# Altium schematic sheet Schematic_Orbita_Microcontroller.SchDoc (continued)

## Library data of the parts
C13 C14 = CMP-2008-02679-1: Manufacturer: AVX Interconnect / Elco; Dielectric: C0G; Insulation Resistance: 100GR; Temperature Coefficient: 30ppm/°C; Voltage: 50V; Case Code (Imperial): 0402; Case Code (Metric): 1005; Case/Package: 0402; Category: Ceramic Capacitors; Component Kind: Standard; ComponentLink1Description: https://datasheet.ciiva.com/11788/0900766b80def0e2-11788526.pdf?src-supplier=RSComponents; ComponentLink1URL: https://datasheet.ciiva.com/11788/0900766b80def0e2-11788526.pdf?src-supplier=RSComponents; ComponentLink2Description: https://datasheet.ciiva.com/2987/ccog-2987665.pdf?src-supplier=Avnet; ComponentLink2URL: https://datasheet.ciiva.com/2987/ccog-2987665.pdf?src-supplier=Avnet; ComponentLink3Description: https://datasheet.ciiva.com/151/ccog-151780.pdf?src-supplier=Digi-Key; ComponentLink3URL: https://datasheet.ciiva.com/151/ccog-151780.pdf?src-supplier=Digi-Key; ComponentLink4Description: https://datasheet.ciiva.com/1123/84496-1123652.pdf?src-supplier=Element14; ComponentLink4URL: https://datasheet.ciiva.com/1123/84496-1123652.pdf?src-supplier=Element14; ComponentLink5Description: https://datasheet.ciiva.com/151/ccog-151781.pdf?src-supplier=Digi-Key; ComponentLink5URL: https://datasheet.ciiva.com/151/ccog-151781.pdf?src-supplier=Digi-Key; ComponentLink6Description: https://datasheet.ciiva.com/1123/84496-1123653.pdf?src-supplier=Element14; ComponentLink6URL: https://datasheet.ciiva.com/1123/84496-1123653.pdf?src-supplier=Element14; ComponentLink7Description: https://datasheet.ciiva.com/151/ccog-151782.pdf?src-supplier=Digi-Key; ComponentLink7URL: https://datasheet.ciiva.com/151/ccog-151782.pdf?src-supplier=Digi-Key; Contact Plating: Tin,Matte; Depth: 0.5mm; Height: 0.5mm; Lead Free: Lead Free; Length: 1mm; Library Name: Altium Content Vault; Manufacturer Part Number: 04025A6R8CAT2A; Max Operating Temperature: 125°C; Min Operating Temperature: -55°C; Mount: Surface Mount,PCB; Package Quantity: 1; Packaging: Tape and Reel; Part Number: 04025A6R8CAT2A; Pricing 1: 1=0,24000, 10=0,16300, 100=0,07300, 500=0,05000, 1000=0,04100, 2500=0,03100 (USD); Radiation Hardening: No; REACH SVHC: No SVHC; RoHS: Yes; Stock 1: 58989; Supplier 1: Mouser; Supplier Part Number 1: 581-04025A6R8C; Termination: SMD/SMT; Thickness: 0.022inch; Voltage Rating: 50V; Voltage Rating (DC): 50V; Width: 0.02inch
C15 = CMP-2100-03641-1: Chip Capacitor, 0.1 uF, +/- 10%, 16 V, -55 to 125 degC, 0402 (1005 Metric), RoHS, Tape and Reel; Manufacturer: Murata; Dielectric: X7R; Dielectric Material: Ceramic; Insulation Resistance: 10GR; Voltage: 16V; Case Code (Imperial): 0402; Case Code (Metric): 1005; Case/Package: 0402; Category: Ceramic Capacitors; Component Kind: Standard; ComponentLink1Description: https://datasheet.ciiva.com/129/grm155r71c104ka88-01-129739.pdf?src-supplier=Digi-Key; ComponentLink1URL: https://datasheet.ciiva.com/129/grm155r71c104ka88-01-129739.pdf?src-supplier=Digi-Key; ComponentLink2Description: https://datasheet.ciiva.com/129/grm155r71c104ka88-01-129740.pdf?src-supplier=Digi-Key; ComponentLink2URL: https://datasheet.ciiva.com/129/grm155r71c104ka88-01-129740.pdf?src-supplier=Digi-Key; ComponentLink3Description: https://datasheet.ciiva.com/18102/getdatasheetpartid-85307-18102905.pdf?src-supplier=Verical; ComponentLink3URL: https://datasheet.ciiva.com/18102/getdatasheetpartid-85307-18102905.pdf?src-supplier=Verical; ComponentLink4Description: https://datasheet.ciiva.com/29199/454098-da-01-en-vs-kondensator-0402-29199799.pdf?src-supplier=Conrad; ComponentLink4URL: https://datasheet.ciiva.com/29199/454098-da-01-en-vs-kondensator-0402-29199799.pdf?src-supplier=Conrad; ComponentLink5Description: https://datasheet.ciiva.com/16199/product-16199000.pdf?src-supplier=Future+Electronics; ComponentLink5URL: https://datasheet.ciiva.com/16199/product-16199000.pdf?src-supplier=Future+Electronics; ComponentLink6Description: https://datasheet.ciiva.com/14116/grmseries-highdielectrictype-eng-14116878.pdf?src-supplier=Distrelec; ComponentLink6URL: https://datasheet.ciiva.com/14116/grmseries-highdielectrictype-eng-14116878.pdf?src-supplier=Distrelec; ComponentLink7Description: https://datasheet.ciiva.com/7937/4360-7937615.pdf?src-supplier=Element14; ComponentLink7URL: https://datasheet.ciiva.com/7937/4360-7937615.pdf?src-supplier=Element14; ComponentLink8Description: https://datasheet.ciiva.com/28534/4360-28534133.pdf?src-supplier=Element14; ComponentLink8URL: https://datasheet.ciiva.com/28534/4360-28534133.pdf?src-supplier=Element14; ComponentLink9Description: https://datasheet.ciiva.com/14103/grmseries-highdielectrictype-eng-14103750.pdf?src-supplier=Distrelec; ComponentLink9URL: https://datasheet.ciiva.com/14103/grmseries-highdielectrictype-eng-14103750.pdf?src-supplier=Distrelec; ComponentLink10Description: https://datasheet.ciiva.com/28534/4360-28534127.pdf?src-supplier=Element14; ComponentLink10URL: https://datasheet.ciiva.com/28534/4360-28534127.pdf?src-supplier=Element14; Datasheet URL: http://psearch.en.murata.com/capacitor/product/GRM155R71C104KA88%23.pdf; Datasheet Version: 42675.0; Depth: 0.5mm; Height: 0.5mm; Lead Free: LeadFree; Length: 1mm; Library Name: Altium Content Vault; Manufacturer Part Number: GRM155R71C104KA88D; Manufacturer URL: http://www.murata.com/; Material: Ceramic; Max Operating Temperature: 125degC; Min Operating Temperature: -55degC; Mount: SurfaceMount; Number of Pins: 2; Package Description: 2-Pin Surface Mount Device, Body 1 x 0.5 mm; Package Quantity: 1; Package Reference: 0402; Packaging: TapeandReel; Pricing 1: 1=0,10000, 10=0,02400, 100=0,01000, 500=0,00700, 1000=0,00500, 2500=0,00500 (USD); Radiation Hardening: No; REACH SVHC: NoSVHC; RoHS: Yes; RoHSCompliant: true; Stock 1: 3630519; Supplier 1: Mouser; Supplier Part Number 1: 81-GRM155R71C104KA88; Termination: SMD/SMT; Thickness: 0.022inch; Tolerance: 10%; Voltage Rating: 16V; Voltage Rating (DC): 16V; Width: 0.5mm
C16 C21-C24 C27 = CMP-2008-02046-1: Manufacturer: Kemet; Dielectric: X5R; Dielectric Material: Ceramic; Dissipation Factor: 5%; Tolerance: 10%; Voltage: 10V; Case Code (Imperial): 0402; Case Code (Metric): 1005; Case/Package: 0402; Category: Ceramic Capacitors; Component Kind: Standard; ComponentLink1Description: https://datasheet.ciiva.com/7747/1776086-7747440.pdf?src-supplier=Element14; ComponentLink1URL: https://datasheet.ciiva.com/7747/1776086-7747440.pdf?src-supplier=Element14; ComponentLink2Description: https://datasheet.ciiva.com/144/docfinderpartnumber-c0402c104k8pactu-144097.pdf?src-supplier=Digi-Key; ComponentLink2URL: https://datasheet.ciiva.com/144/docfinderpartnumber-c0402c104k8pactu-144097.pdf?src-supplier=Digi-Key; ComponentLink3Description: https://datasheet.ciiva.com/14595/70095383-14595064.pdf?src-supplier=Allied+Electronics; ComponentLink3URL: https://datasheet.ciiva.com/14595/70095383-14595064.pdf?src-supplier=Allied+Electronics; ComponentLink4Description: https://datasheet.ciiva.com/14588/70095383-14588187.pdf?src-supplier=Allied+Electronics; ComponentLink4URL: https://datasheet.ciiva.com/14588/70095383-14588187.pdf?src-supplier=Allied+Electronics; ComponentLink5Description: https://datasheet.ciiva.com/28645/1789732-28645387.pdf?src-supplier=Element14; ComponentLink5URL: https://datasheet.ciiva.com/28645/1789732-28645387.pdf?src-supplier=Element14; Contact Plating: Tin,Matte; Depth: 0.5mm; Height: 0.5mm; Lead Free: Lead Free; Lead Pitch: 0.3mm; Length: 1mm; Library Name: Altium Content Vault; Manufacturer Part Number: C0402C104K8PACTU; Max Operating Temperature: 85°C; Min Operating Temperature: -55°C; Mount: Surface Mount,PCB; Number of Pins: 2; Package Quantity: 1; Packaging: Tape and Reel; Part Number: C0402C104K8PACTU; Pricing 1: 1=0,25000, 10=0,05500, 100=0,03300, 500=0,02800, 1000=0,02200, 2000=0,01800 (USD); Radiation Hardening: No; REACH SVHC: No SVHC; RoHS: Yes; Stock 1: 975753; Supplier 1: Mouser; Supplier Part Number 1: 80-C0402C104K8P; Termination: SMD/SMT; Thickness: 0.022inch; Voltage Rating: 10V; Voltage Rating (DC): 10V; Width: 0.02inch
C17 = CMP-2008-03070-1: Manufacturer: Kemet; Dielectric: X7R; Dielectric Material: Ceramic; Dissipation Factor: 3.5%; Tolerance: 10%; Voltage: 25V; Case Code (Imperial): 0402; Case Code (Metric): 1005; Case/Package: 0402; Category: Ceramic Capacitors; Component Kind: Standard; ComponentLink1Description: https://datasheet.ciiva.com/45846/docfinderpartnumberc0402c102k5ractu-45846917.pdf?src-supplier=Onlinecomponents.com; ComponentLink1URL: https://datasheet.ciiva.com/45846/docfinderpartnumberc0402c102k5ractu-45846917.pdf?src-supplier=Onlinecomponents.com; ComponentLink2Description: https://datasheet.ciiva.com/7747/kem-c1002-x7r-smd-7747612.pdf?src-supplier=Element14; ComponentLink2URL: https://datasheet.ciiva.com/7747/kem-c1002-x7r-smd-7747612.pdf?src-supplier=Element14; ComponentLink3Description: https://datasheet.ciiva.com/18192/getdatasheetpartid-330802-18192396.pdf?src-supplier=Verical; ComponentLink3URL: https://datasheet.ciiva.com/18192/getdatasheetpartid-330802-18192396.pdf?src-supplier=Verical; Depth: 0.5mm; Height: 0.5mm; Length: 1mm; Library Name: Altium Content Vault; Manufacturer Part Number: C0402C103K3RACTU; Max Operating Temperature: 125°C; Min Operating Temperature: -55°C; Mount: Surface Mount; Package Quantity: 10000; Packaging: Tape and Reel; Part Number: C0402C103K3RACTU; Pricing 1: 1=0,10000, 10=0,01900, 100=0,01100, 500=0,00900, 1000=0,00600, 2000=0,00500 (USD); Radiation Hardening: No; RoHS: Yes; Stock 1: 423830; Supplier 1: Mouser; Supplier Part Number 1: 80-C0402C103K3R; Termination: SMD/SMT; Voltage Rating: 25V; Voltage Rating (DC): 25V; Width: 0.5mm
C18 C19 = CMP-2008-02081-1: Manufacturer: Kemet; Manufacturer Part Number: C0402C105K8PACTU; Dielectric: X5R; Dielectric Material: Ceramic; Dissipation Factor: 5%; Tolerance: 10%; Voltage: 10V; Case Code (Imperial): 0402; Case Code (Metric): 1005; Case/Package: 0402; Category: Ceramic Capacitors; Component Kind: Standard; ComponentLink1Description: https://datasheet.ciiva.com/16623/datasheetpn-c0402c105k8pac7867-16623492.pdf?src-supplier=Avnet+Europe; ComponentLink1URL: https://datasheet.ciiva.com/16623/datasheetpn-c0402c105k8pac7867-16623492.pdf?src-supplier=Avnet+Europe; ComponentLink2Description: https://datasheet.ciiva.com/7747/40889-7747622.pdf?src-supplier=Element14; ComponentLink2URL: https://datasheet.ciiva.com/7747/40889-7747622.pdf?src-supplier=Element14; ComponentLink3Description: https://datasheet.ciiva.com/28610/1918694-28610762.pdf?src-supplier=Element14; ComponentLink3URL: https://datasheet.ciiva.com/28610/1918694-28610762.pdf?src-supplier=Element14; ComponentLink4Description: https://datasheet.ciiva.com/22693/1929483-22693871.pdf?src-supplier=Element14; ComponentLink4URL: https://datasheet.ciiva.com/22693/1929483-22693871.pdf?src-supplier=Element14; ComponentLink5Description: https://datasheet.ciiva.com/28645/1789732-28645391.pdf?src-supplier=Element14; ComponentLink5URL: https://datasheet.ciiva.com/28645/1789732-28645391.pdf?src-supplier=Element14; Contact Plating: Tin,Matte; Depth: 0.5mm; Height: 0.5mm; Lead Free: Lead Free; Length: 1mm; Library Name: Altium Content Vault; Max Operating Temperature: 85°C; Min Operating Temperature: -55°C; Mount: Surface Mount; Number of Pins: 2; Package Quantity: 1; Packaging: Tape and Reel; Part Number: C0402C105K8PAC7867; Pricing 1: 1=0,12000, 10=0,08700, 100=0,04000, 500=0,02800, 1000=0,02300, 2000=0,02000 (USD); Radiation Hardening: No; REACH SVHC: No SVHC; RoHS: Yes; Stock 1: 98757; Supplier 1: Mouser; Supplier Part Number 1: 80-C0402C105K8P; Termination: SMD/SMT; Thickness: 0.022inch; Voltage Rating: 10V; Voltage Rating (DC): 10V; Width: 0.5mm
C20 = CMP-2008-04549-1: Manufacturer: Murata; Dielectric: X5R; Tolerance: 20%; Voltage: 6.3V; Case Code (Imperial): 0402; Case Code (Metric): 1005; Case/Package: 0402; Category: Ceramic Capacitors; Component Kind: Standard; ComponentLink1Description: https://datasheet.ciiva.com/6270/product-6270483.pdf?src-supplier=Digi-Key; ComponentLink1URL: https://datasheet.ciiva.com/6270/product-6270483.pdf?src-supplier=Digi-Key; ComponentLink2Description: https://datasheet.ciiva.com/6268/partnumbering-e-01-6268378.pdf?src-supplier=Digi-Key; ComponentLink2URL: https://datasheet.ciiva.com/6268/partnumbering-e-01-6268378.pdf?src-supplier=Digi-Key; ComponentLink3Description: https://datasheet.ciiva.com/46418/1769893-46418044.pdf?src-supplier=Element14; ComponentLink3URL: https://datasheet.ciiva.com/46418/1769893-46418044.pdf?src-supplier=Element14; ComponentLink4Description: https://datasheet.ciiva.com/46418/1769893-46418045.pdf?src-supplier=Element14; ComponentLink4URL: https://datasheet.ciiva.com/46418/1769893-46418045.pdf?src-supplier=Element14; ComponentLink5Description: https://datasheet.ciiva.com/6268/partnumbering-e-01-6268382.pdf?src-supplier=Digi-Key; ComponentLink5URL: https://datasheet.ciiva.com/6268/partnumbering-e-01-6268382.pdf?src-supplier=Digi-Key; Contact Plating: Tin; Depth: 0.5mm; Height: 0.5mm; Lead Free: Lead Free; Length: 1mm; Library Name: Altium Content Vault; Manufacturer Part Number: GRM155R60J475ME47D; Max Operating Temperature: 85°C; Min Operating Temperature: -55°C; Mount: Surface Mount; Package Quantity: 10000; Packaging: Tape and Reel; Part Number: GRM155R60J475ME47D; Pricing 1: 1=0,15000, 10=0,11400, 100=0,05600, 500=0,03500, 1000=0,02900, 2500=0,02700 (USD); REACH SVHC: No SVHC; RoHS: Yes; Stock 1: 911879; Supplier 1: Mouser; Supplier Part Number 1: 81-GRM155R60J475ME7D; Termination: SMD/SMT; Thickness: 0.022inch; Voltage Rating: 6.3V; Voltage Rating (DC): 6.3V; Weight: 0.000212oz; Width: 0.02inch
C25 = CMP-2007-03541-1: Manufacturer: Murata; Dielectric: X5R; Material: Ceramic; Tolerance: 10%; Voltage: 25V; Case Code (Imperial): 0805; Case Code (Metric): 2012; Case/Package: 0805; Category: Ceramic Capacitors; Component Kind: Standard; ComponentLink1Description: https://datasheet.ciiva.com/28584/626718-28584288.pdf?src-supplier=Element14; ComponentLink1URL: https://datasheet.ciiva.com/28584/626718-28584288.pdf?src-supplier=Element14; ComponentLink2Description: https://datasheet.ciiva.com/16440/grm21br61e106ka73l-16440627.pdf?src-supplier=Future+Electronics; ComponentLink2URL: https://datasheet.ciiva.com/16440/grm21br61e106ka73l-16440627.pdf?src-supplier=Future+Electronics; ComponentLink3Description: https://datasheet.ciiva.com/5424/product-5424327.pdf?src-supplier=Mouser; ComponentLink3URL: https://datasheet.ciiva.com/5424/product-5424327.pdf?src-supplier=Mouser; ComponentLink4Description: https://datasheet.ciiva.com/22774/1747469-22774396.pdf?src-supplier=Farnell; ComponentLink4URL: https://datasheet.ciiva.com/22774/1747469-22774396.pdf?src-supplier=Farnell; ComponentLink5Description: https://datasheet.ciiva.com/29199/454623-da-01-en-vs-kondensator-0805-29199615.pdf?src-supplier=Conrad; ComponentLink5URL: https://datasheet.ciiva.com/29199/454623-da-01-en-vs-kondensator-0805-29199615.pdf?src-supplier=Conrad; ComponentLink6Description: https://datasheet.ciiva.com/28584/626718-28584279.pdf?src-supplier=Element14; ComponentLink6URL: https://datasheet.ciiva.com/28584/626718-28584279.pdf?src-supplier=Element14; ComponentLink7Description: https://datasheet.ciiva.com/139/partnumbering-e-01-139182.pdf?src-supplier=Digi-Key; ComponentLink7URL: https://datasheet.ciiva.com/139/partnumbering-e-01-139182.pdf?src-supplier=Digi-Key; ComponentLink8Description: https://datasheet.ciiva.com/139/partnumbering-e-01-139184.pdf?src-supplier=Digi-Key; ComponentLink8URL: https://datasheet.ciiva.com/139/partnumbering-e-01-139184.pdf?src-supplier=Digi-Key; ComponentLink9Description: https://datasheet.ciiva.com/7719/1747469-7719093.pdf?src-supplier=Element14; ComponentLink9URL: https://datasheet.ciiva.com/7719/1747469-7719093.pdf?src-supplier=Element14; ComponentLink10Description: https://datasheet.ciiva.com/14116/grmseries-highdielectrictype-eng-14116376.pdf?src-supplier=Distrelec; ComponentLink10URL: https://datasheet.ciiva.com/14116/grmseries-highdielectrictype-eng-14116376.pdf?src-supplier=Distrelec; ComponentLink11Description: https://datasheet.ciiva.com/139/partnumbering-e-01-139183.pdf?src-supplier=Digi-Key; ComponentLink11URL: https://datasheet.ciiva.com/139/partnumbering-e-01-139183.pdf?src-supplier=Digi-Key; Depth: 1.25mm; Height: 1.25mm; Lead Free: Lead Free; Length: 2mm; Library Name: Altium Content Vault; Manufacturer Part Number: GRM21BR61E106KA73L; Max Operating Temperature: 85°C; Min Operating Temperature: -55°C; Mount: Surface Mount; Package Quantity: 1; Packaging: Tape and Reel; Part Number: GRM21BR61E106KA73L; Pricing 1: 1=0,39000, 10=0,26700, 100=0,17500, 500=0,13100, 1000=0,11100, 3000=0,09100 (USD); Radiation Hardening: No; REACH SVHC: Unknown; RoHS: Yes; Stock 1: 211262; Supplier 1: Mouser; Supplier Part Number 1: 81-GRM21BR61E106KA3L; Termination: SMD/SMT; Thickness: 0.053inch; Voltage Rating: 25V; Voltage Rating (DC): 25V; Width: 0.049inch
C26 = CMP-1036-04939-1: CAP 22uF 6.3V ±20% 0805 (2012 Metric) Thickness 1.45mm SMD; Manufacturer: Kyocera AVX; Manufacturer Part Number: 08056D226MAT2A; Case-EIA: 0805; Case-Metric: 2012; Dielectric: X5R; Dielectric Material: Ceramic; Dissipation Factor: 12.5%; Insulation Resistance: 10GR; Tolerance: Â±20%; Case Code (Imperial): 0805; Case Code (Metric): 2012; Case/Package: 0805; Category: Ceramic Capacitors; Component Kind: Standard; ComponentLink1Description: https://datasheet.ciiva.com/46385/759405-46385942.pdf?src-supplier=Element14; ComponentLink1URL: https://datasheet.ciiva.com/46385/759405-46385942.pdf?src-supplier=Element14; ComponentLink2Description: https://datasheet.ciiva.com/157/cx5r-157915.pdf?src-supplier=Digi-Key; ComponentLink2URL: https://datasheet.ciiva.com/157/cx5r-157915.pdf?src-supplier=Digi-Key; ComponentLink3Description: https://datasheet.ciiva.com/7812/1598274-7812187.pdf?src-supplier=Element14; ComponentLink3URL: https://datasheet.ciiva.com/7812/1598274-7812187.pdf?src-supplier=Element14; ComponentLink4Description: https://datasheet.ciiva.com/22703/1598274-22703227.pdf?src-supplier=Element14; ComponentLink4URL: https://datasheet.ciiva.com/22703/1598274-22703227.pdf?src-supplier=Element14; ComponentLink5Description: https://datasheet.ciiva.com/2988/cx5r-2988046.pdf?src-supplier=Avnet; ComponentLink5URL: https://datasheet.ciiva.com/2988/cx5r-2988046.pdf?src-supplier=Avnet; ComponentLink6Description: https://datasheet.ciiva.com/28592/759405-28592847.pdf?src-supplier=Element14; ComponentLink6URL: https://datasheet.ciiva.com/28592/759405-28592847.pdf?src-supplier=Element14; ComponentLink7Description: https://datasheet.ciiva.com/7812/lt-7812359.pdf?src-supplier=Element14; ComponentLink7URL: https://datasheet.ciiva.com/7812/lt-7812359.pdf?src-supplier=Element14; ComponentLink8Description: https://datasheet.ciiva.com/14598/70001028-14598969.pdf?src-supplier=Allied+Electronics; ComponentLink8URL: https://datasheet.ciiva.com/14598/70001028-14598969.pdf?src-supplier=Allied+Electronics; ComponentLink9Description: https://datasheet.ciiva.com/157/cx5r-157916.pdf?src-supplier=Digi-Key; ComponentLink9URL: https://datasheet.ciiva.com/157/cx5r-157916.pdf?src-supplier=Digi-Key; ComponentLink10Description: https://datasheet.ciiva.com/157/cx5r-157917.pdf?src-supplier=Digi-Key; ComponentLink10URL: https://datasheet.ciiva.com/157/cx5r-157917.pdf?src-supplier=Digi-Key; Depth: 1.25mm; Height: 1.4mm; Lead Free: Lead Free; Length: 2.01mm; Library Name: Altium Content Vault; Max Operating Temperature: 85°C; Max Thickness: 1.45 mm; Min Operating Temperature: -55°C; Mount: Surface Mount,PCB; Number of Pins: 2; Package Quantity: 1; Packaging: Tape and Reel; Pricing 1: 1=0,70000, 10=0,49200, 100=0,32400, 500=0,24000, 1000=0,20400, 3000=0,16700 (USD); Radiation Hardening: No; Rated Voltage: 6.3 V; REACH SVHC: No SVHC; RoHS: Yes; Stock 1: 220672; Supplier 1: Mouser; Supplier Part Number 1: 581-08056D226M; Technology: SMT; Termination: SMD/SMT; Thickness: 0.055inch; Voltage: 6.3V; Voltage Rating: 6.3V; Voltage Rating (DC): 6.3V; Width: 0.049inch
D1 = CMP-2000-05378-1: Very low capacitance ESD protection, 3.5 pF, -40 to 125 degC, 6-Pin SOT23, RoHS, Tape and Reel; Manufacturer: STMicroelectronics; Breakdown Voltage: 6V; Capacitance: 3.5pF; Clamping Voltage: 17V; Configuration: Dual; Data Rate: 480Mbps; Direction: Unidirectional,Bidirectional; Direction Type: Uni-Directional; ESD Protection: Yes; Leakage Current: 0.15uA; Max Reverse Leakage Current: 150nA; Number of Bidirectional Channels: 2; Number of Elements: 1; Operating Supply Voltage: 1.1V; Peak Pulse Current: 5A; Product Depth (mm): 1.75mm; Product Height (mm): 1.3mm; Product Length (mm): 3.05mm; Rad Hardened: No; Reverse Stand-off Voltage: 5V; Reverse Standoff Voltage: 5.25V; Suppressor Type: DiodeArrays; Test Current: 1mA; Capacitance Value: 3.5pF; Case/Package: SOT-23; Category: TVS Diodes; Clamping Voltage (Max): 17V; Component Kind: Standard; ComponentLink1Description: https://datasheet.ciiva.com/1268/1690354-1268384.pdf?src-supplier=Element14; ComponentLink1URL: https://datasheet.ciiva.com/1268/1690354-1268384.pdf?src-supplier=Element14; ComponentLink2Description: https://datasheet.ciiva.com/4508/cd00050750-113117-4508433.pdf?src-supplier=Mouser; ComponentLink2URL: https://datasheet.ciiva.com/4508/cd00050750-113117-4508433.pdf?src-supplier=Mouser; ComponentLink3Description: https://datasheet.ciiva.com/15143/getdatasheetpartid-148465-15143789.pdf?src-supplier=Verical; ComponentLink3URL: https://datasheet.ciiva.com/15143/getdatasheetpartid-148465-15143789.pdf?src-supplier=Verical; ComponentLink4Description: https://datasheet.ciiva.com/26787/usblc6-2sc6-26787908.pdf?src-supplier=IIIC; ComponentLink4URL: https://datasheet.ciiva.com/26787/usblc6-2sc6-26787908.pdf?src-supplier=IIIC; ComponentLink5Description: https://datasheet.ciiva.com/16200/cd00050750-16200026.pdf?src-supplier=Future+Electronics; ComponentLink5URL: https://datasheet.ciiva.com/16200/cd00050750-16200026.pdf?src-supplier=Future+Electronics; ComponentLink6Description: https://datasheet.ciiva.com/41444/001184109-da-01-en-ic-esd-protecti-usblc6-2sc6-sot-23-6-stm-41444156.pdf?src-supplier=Conrad; ComponentLink6URL: https://datasheet.ciiva.com/41444/001184109-da-01-en-ic-esd-protecti-usblc6-2sc6-sot-23-6-stm-41444156.pdf?src-supplier=Conrad; Contact Plating: Tin,Matte; Datasheet URL: http://www.st.com/st-web-ui/static/active/en/resource/technical/document/datasheet/CD00050750.pdf; Datasheet Version: Rev. 5, 10/2011; Depth: 1.75mm; Element Configuration: Dual; Height: 1.3mm; Lead Free: Lead Free; Leakage Current (Max): 0.15uA; Length: 3.05mm; Library Name: Altium Content Vault; Manufacturer Part Number: USBLC6-2SC6; Manufacturer URL: http://www.st.com/; Max Breakdown Voltage: 6V; Max Operating Temperature: 125°C; Min Breakdown Voltage: 6V; Min Operating Temperature: -40°C; Mount: Surface Mount; Mounting: SurfaceMount; Mounting Style: SurfaceMount; Number of Channels: 2; Number of Pins: 6; Operating Temp Range: -40Cto125C; Operating Temperature Classification: Automotive; Operating Temperature Max Deg. C: 125C; Operating Temperature Min Deg. C: -40C; Package / Case: SOT-23; Package Description: 6-Pin Small Outline Transistor, Body 2.925 x 1.625 mm, Pitch 0.95 mm; Package Quantity: 3000; Package Reference: SOT23-6L; Packaging: TapeandReel; Pin Count: 6; Polarity: Uni-Directional; Power Line Protection: Yes; Pricing 1: 1=0,45000, 10=0,37400, 100=0,22800, 1000=0,17600, 3000=0,15000, 9000=0,14000 (USD); Radiation Hardening: No; REACH SVHC: No SVHC; Reverse Breakdown Voltage: 6V; RoHS: Yes; Stock 1: 29344; Supplier 1: Mouser; Supplier Part Number 1: 511-USBLC6-2SC6; Termination: SMD/SMT; Test Current (It): 1mA; Width: 1.75mm; Working Voltage: 5V; Working Voltage (Max): 5V
D2 = CMP-1060-00363-1: Zener Voltage Regulator, 500 mW, 2-Pin SOD-123, Pb-Free, Tape and Reel; Manufacturer: On Semiconductor; Breakdown Voltage: 3.47V; ESD Protection: Yes; Forward Voltage: 900mV; Impedance: 28R; Material: Plastic; Max Power Dissipation: 500mW; Max Reverse Leakage Current: 25uA; P Max (W): 0.5; Test Current: 20mA; Tolerance: 5%; Voltage Rating (DC): 3.3V; VZ Typ (V): 3.3; Zener Current: 10mA; Case/Package: SOD-123-2; Category: Zener Diodes; Component Kind: Standard; ComponentLink1Description: Manufacturer URL; ComponentLink1URL: http://www.onsemi.com/; ComponentLink2Description: Datasheet; ComponentLink2URL: http://www.onsemi.com/pub_link/Collateral/MMSZ5221BT1-D.PDF; ComponentLink3Description: Package Specification; ComponentLink3URL: http://www.onsemi.com/pub_link/Collateral/425-04.PDF; ComponentLink4Description: https://datasheet.ciiva.com/34303/2011758-34303827.pdf?src-supplier=Jameco; ComponentLink4URL: https://datasheet.ciiva.com/34303/2011758-34303827.pdf?src-supplier=Jameco; ComponentLink5Description: https://datasheet.ciiva.com/15142/getdatasheetpartid-93094-15142584.pdf?src-supplier=Verical; ComponentLink5URL: https://datasheet.ciiva.com/15142/getdatasheetpartid-93094-15142584.pdf?src-supplier=Verical; ComponentLink6Description: https://datasheet.ciiva.com/895/mmsz5221bt1-d-895120.pdf?src-supplier=Digi-Key; ComponentLink6URL: https://datasheet.ciiva.com/895/mmsz5221bt1-d-895120.pdf?src-supplier=Digi-Key; ComponentLink7Description: https://datasheet.ciiva.com/8346/mmsz5221bt1-d-8346168.pdf?src-supplier=Avnet; ComponentLink7URL: https://datasheet.ciiva.com/8346/mmsz5221bt1-d-8346168.pdf?src-supplier=Avnet; ComponentLink8Description: https://datasheet.ciiva.com/16206/mmsz5221bt1-d-16206778.pdf?src-supplier=Future+Electronics; ComponentLink8URL: https://datasheet.ciiva.com/16206/mmsz5221bt1-d-16206778.pdf?src-supplier=Future+Electronics; ComponentLink9Description: https://datasheet.ciiva.com/8346/mmsz5221bt1-d-8346172.pdf?src-supplier=Avnet; ComponentLink9URL: https://datasheet.ciiva.com/8346/mmsz5221bt1-d-8346172.pdf?src-supplier=Avnet; ComponentLink10Description: https://datasheet.ciiva.com/895/mmsz5221bt1-d-895118.pdf?src-supplier=Digi-Key; ComponentLink10URL: https://datasheet.ciiva.com/895/mmsz5221bt1-d-895118.pdf?src-supplier=Digi-Key; ComponentLink11Description: https://datasheet.ciiva.com/46395/86126-46395247.pdf?src-supplier=Element14; ComponentLink11URL: https://datasheet.ciiva.com/46395/86126-46395247.pdf?src-supplier=Element14; ComponentLink12Description: https://datasheet.ciiva.com/25926/mmsz5221bt1-d-25926109.pdf?src-supplier=Avnet+Europe; ComponentLink12URL: https://datasheet.ciiva.com/25926/mmsz5221bt1-d-25926109.pdf?src-supplier=Avnet+Europe; ComponentLink13Description: https://datasheet.ciiva.com/895/mmsz5221bt1-d-895119.pdf?src-supplier=Digi-Key; ComponentLink13URL: https://datasheet.ciiva.com/895/mmsz5221bt1-d-895119.pdf?src-supplier=Digi-Key; Contact Plating: Tin,Matte; DatasheetVersion: Rev. 12; Height: 1.12mm; Lead Free: Lead Free; Length: 2.69mm; Library Name: Altium Content Vault; Manufacturer Part Number: MMSZ5226BT1G; Max Operating Temperature: 150°C; Min Operating Temperature: -55°C; Mounting Technology: Surface Mount; Number of Pins: 2; Package Quantity: 1; PackageDescription: 2-Pin SOD, Body 1.6 x 2.69 mm; PackageReference: SOD-123-2-425-04; PackageVersion: Rev. G, 10/2009; Packaging: Tape and Reel; Packing: Tape and Reel; PartNumber: MMSZ5226BT1G; Peak Reverse Current: 25uA; Power Dissipation: 500mW; Power Rating: 500mW; Pricing 1: 1=0,20000, 10=0,18900, 100=0,06600, 1000=0,04400, 3000=0,03300, 9000=0,02900 (USD); Radiation Hardening: No; REACH SVHC: No SVHC; RoHS: Pb-Free; Stock 1: 37132; Supplier 1: Mouser; Supplier Part Number 1: 863-MMSZ5226BT1G; Voltage Tolerance: 5%; Width: 1.6mm; Working Voltage: 3.3V; Zener Voltage: 3.3V
D4 = APHD1608LVBC/D: Standard LEDs; SMD 0613 Dome Lens LED; 1.6x0.8 mm; Blue; (Cut Reel); Manufacturer: Kingbright; Manufacturer Part Number: APTD1608LVBC/D; Dominant Wavelength: 470nm; Forward Voltage: 2.65V; Lens Color: Colorless; Lens Transparency: Clear; Luminous Intensity: 65mcd; Test Current: 2mA; Viewing Angle: 40°; Case Code (Imperial): 0603; Case Code (Metric): 1608; Case/Package: 0603; Category: LEDs; Color: Blue; Component Kind: Standard; ComponentLink1Description: http://www.mouser.com/ds/2/216/APTD1608LVBC-D-535841.pdf?src-supplier=Mouser; ComponentLink1URL: http://www.mouser.com/ds/2/216/APTD1608LVBC-D-535841.pdf?src-supplier=Mouser; ComponentLink2Description: http://www.kingbrightusa.com/images/catalog/SPEC/APTD1608LVBC-D.pdf?src-supplier=Digi-Key; ComponentLink2URL: http://www.kingbrightusa.com/images/catalog/SPEC/APTD1608LVBC-D.pdf?src-supplier=Digi-Key; Height: 0.95mm; Length: 1.6mm; Mount: Surface Mount; Packaging: Tape and Reel; Pricing 1: 1=0,59000, 10=0,40700, 100=0,29600, 500=0,25700, 1000=0,19800, 2000=0,18700 (USD); RoHS: Yes; Stock 1: 832; Supplier 1: Mouser; Supplier Part Number 1: 604-APTD1608LVBCD; Width: 0.8mm; Library Name: IntegratedLibProject_Orbita.IntLib
J1 = DF11-8DP-2DSA(08): Headers & Wire Housings 2MM V PCB HEADER 8P DR THRU-HOLE TIN; Manufacturer: Hirose; Connector Type: Male Pin; Contact Resistance: 30mR; Current Rating: 2A; Gender: Male; Number of Contacts: 8; Row Spacing: 0.079inch; Voltage Rating: 250V; Category: Board to Board Connectors; Color: Black; Component Kind: Standard; ComponentLink1Description: https://datasheet.ciiva.com/11752/0900766b8080dda0-11752290.pdf?src-supplier=RSComponents; ComponentLink1URL: https://datasheet.ciiva.com/11752/0900766b8080dda0-11752290.pdf?src-supplier=RSComponents; ComponentLink2Description: https://datasheet.ciiva.com/26897/getdatasheetpartid-456442-26897615.pdf?src-supplier=Verical; ComponentLink2URL: https://datasheet.ciiva.com/26897/getdatasheetpartid-456442-26897615.pdf?src-supplier=Verical; ComponentLink3Description: https://datasheet.ciiva.com/16431/en-df11-20130411-16431943.pdf?src-supplier=Future+Electronics; ComponentLink3URL: https://datasheet.ciiva.com/16431/en-df11-20130411-16431943.pdf?src-supplier=Future+Electronics; ComponentLink4Description: https://datasheet.ciiva.com/5154/en-df11-20130411-258217-5154649.pdf?src-supplier=Mouser; ComponentLink4URL: https://datasheet.ciiva.com/5154/en-df11-20130411-258217-5154649.pdf?src-supplier=Mouser; Contact Material: Brass,Copper Alloy; Contact Mating Length: 0.118inch; Contact Plating: Tin; Depth: 5mm; Fastening Type: Detent; Housing Color: Black; Housing Material: Polyamide; Lead Free: Lead Free; Length: 10mm; Manufacturer Part Number: DF11-8DP-2DSA(08); Max Current Rating: 2A; Max Operating Temperature: 85°C; Min Operating Temperature: -30°C; Mount: Through Hole; Number of Positions: 8; Number of Rows: 2; Orientation: Straight; Package Quantity: 100; Packaging: Rail/Tube; Pitch: 2mm; Pricing 1: 10=0,17600, 250=0,13700, 1000=0,10800, 5000=0,08800, 10000=0,08400 (EUR); Pricing 2: 1=0,28000, 10=0,20700, 100=0,16300, 500=0,15600, 1000=0,13000, 2500=0,10400 (USD); Radiation Hardening: No; REACH SVHC: No SVHC; RoHS: Yes; Stock 1: 1010; Stock 2: 2434; Supplier 1: RSComponents; Supplier 2: Mouser; Supplier Part Number 1: 6056857; Supplier Part Number 2: 798-DF11-8DP-2DSA08; Termination: Solder; Library Name: IntegratedSchematicLib_OrbitaProject.SchLib
J2 = CMP-1241-00368-1: 0.5 mm Pitch Easy-On(TM) Type FPC Connector, 2.0 mm Mated Height, Right Angle, ZIF, SMT, Bottom Contact, 6 Circuits, -20 to 85 degC, ELV and RoHS Compliant, Tape and Reel; Manufacturer: Molex; Circuits (Loaded): 6; Contact Resistance: 20mR; Current (Max per Contact): 0.5A; Current Rating: 500mA; Durability (mating cycles max): 20; Entry Angle: 90degrees Angle; Gender: Female; Mated Height: 2.00mm; Material - Metal: Phosphor Bronze; Material - Plating Mating: Gold; PCB Locator: No; PCB Retention: Yes; Pitch: 0.5mm; Pitch - Mating Interface: 0.50mm; Voltage (Max): 50V; Category: FFC / FPC; Component Kind: Standard; ComponentLink1Description: Manufacturer URL; ComponentLink1URL: http://www.molex.com/molex/index.jsp; ComponentLink2Description: Datasheet; ComponentLink2URL: http://www.molex.com/webdocs/datasheets/pdf/en-us/0527460671_FFC_FPC_CONNECTORS.pdf; ComponentLink3Description: Package Specification; ComponentLink3URL: http://www.molex.com/pdm_docs/sd/527460671_sd.pdf; ComponentLink4Description: https://datasheet.ciiva.com/3112/0527460671-ffc-fpc-connectors-3112192.pdf?src-supplier=Avnet; ComponentLink4URL: https://datasheet.ciiva.com/3112/0527460671-ffc-fpc-connectors-3112192.pdf?src-supplier=Avnet; ComponentLink5Description: https://datasheet.ciiva.com/11745/0900766b80dfdcc6-11745364.pdf?src-supplier=RSComponents; ComponentLink5URL: https://datasheet.ciiva.com/11745/0900766b80dfdcc6-11745364.pdf?src-supplier=RSComponents; ComponentLink6Description: https://datasheet.ciiva.com/5137/0527460671-ffc-fpc-connectors-170646-5137484.pdf?src-supplier=Mouser; ComponentLink6URL: https://datasheet.ciiva.com/5137/0527460671-ffc-fpc-connectors-170646-5137484.pdf?src-supplier=Mouser; ComponentLink7Description: https://datasheet.ciiva.com/16659/0527460671-ffc-fpc-connectors-16659910.pdf?src-supplier=Avnet+Europe; ComponentLink7URL: https://datasheet.ciiva.com/16659/0527460671-ffc-fpc-connectors-16659910.pdf?src-supplier=Avnet+Europe; ComponentLink8Description: https://datasheet.ciiva.com/15226/getdatasheetpartid-663713-15226332.pdf?src-supplier=Verical; ComponentLink8URL: https://datasheet.ciiva.com/15226/getdatasheetpartid-663713-15226332.pdf?src-supplier=Verical; Contact Location: Bottom; Contact Material: Bronze; Contact Plating: Gold; Contact Position: Bottom; Depth: 4.3mm; ELV: Compliant; Flammability Rating: UL94 V-0; Housing Color: Natural; Housing Material: Nylon; Length: 9.1mm; Library Name: Altium Content Vault; Manufacturer Part Number: 52746-0671; Material: Bronze; Material - Plating Termination: Gold; Max Operating Temperature: 85°C; Min Operating Temperature: -20°C; Mounting Technology: Surface Mount; Number of Circuits: 6; Number of Contacts: 6; Number of Positions: 6; Number of Rows: 1; Orientation: Right Angle; Package Quantity: 1000; PackageDescription: 6-Lead FPC Connector, Pitch 0.5 mm; PackageReference: 52746-0671; PackageVersion: Rev. H, 05/2009; Packaging: Tape and Reel; Packing: Tape and Reel; PartNumber: 52746-0671; Polarized to PCB: Yes; Pricing 1: 1=0,87000, 10=0,69700, 100=0,60300, 500=0,54200, 1000=0,43600, 2000=0,40600 (USD); REACH SVHC: Unknown; RoHS: TRUE; Stackable: No; Stock 1: 11458; Supplier 1: Mouser; Supplier Part Number 1: 538-52746-0671; Termination: Solder; Voltage Rating: 50V
J3 = CMP-1241-00175-1: 1.0 mm Pitch Easy-On(TM) Type FPC Connector, 2.7 mm Mated Height, Right Angle, ZIF, SMT, Top Contact, 6 Circuits, -30 to 85 degC, ELV and RoHS Compliant, Tape and Reel; Manufacturer: Molex; Circuits (Loaded): 6; Contact Resistance: 20mR; Current (Max per Contact): 1A; Durability (mating cycles max): 30; Entry Angle: 90degrees Angle; Gender: Receptacle; Mated Height: 2.70mm; Material - Metal: Phosphor Bronze; Material - Plating Mating: Gold; Material - Plating Termination: Tin; PCB Locator: No; PCB Retention: Yes; Pitch: 1mm; Pitch - Mating Interface: 1.00mm; Voltage (Max): 125V; Category: FFC / FPC; Component Kind: Standard; ComponentLink1Description: Manufacturer URL; ComponentLink1URL: http://www.molex.com/molex/index.jsp; ComponentLink2Description: Datasheet; ComponentLink2URL: http://www.molex.com/webdocs/datasheets/pdf/en-us/0522070660_FFC_FPC_CONNECTORS.pdf; ComponentLink3Description: Package Specification; ComponentLink3URL: http://www.molex.com/pdm_docs/sd/522070660_sd.pdf; ComponentLink4Description: https://datasheet.ciiva.com/11745/0900766b80d6d4e7-11745263.pdf?src-supplier=RSComponents; ComponentLink4URL: https://datasheet.ciiva.com/11745/0900766b80d6d4e7-11745263.pdf?src-supplier=RSComponents; ComponentLink5Description: https://datasheet.ciiva.com/26929/getdatasheetpartid-761355-26929848.pdf?src-supplier=Verical; ComponentLink5URL: https://datasheet.ciiva.com/26929/getdatasheetpartid-761355-26929848.pdf?src-supplier=Verical; ComponentLink6Description: https://datasheet.ciiva.com/5137/0522070660-ffc-fpc-connectors-149417-5137997.pdf?src-supplier=Mouser; ComponentLink6URL: https://datasheet.ciiva.com/5137/0522070660-ffc-fpc-connectors-149417-5137997.pdf?src-supplier=Mouser; ComponentLink7Description: https://datasheet.ciiva.com/16658/0522070660-ffc-fpc-connectors-16658853.pdf?src-supplier=Avnet+Europe; ComponentLink7URL: https://datasheet.ciiva.com/16658/0522070660-ffc-fpc-connectors-16658853.pdf?src-supplier=Avnet+Europe; ComponentLink8Description: https://datasheet.ciiva.com/1438/522071060-sd-1438423.pdf?src-supplier=Element14; ComponentLink8URL: https://datasheet.ciiva.com/1438/522071060-sd-1438423.pdf?src-supplier=Element14; Contact Material: Bronze; Contact Plating: Gold; Contact Position: Top; Current Rating: 1A; Depth: 8.9mm; ELV: Compliant; Flammability Rating: UL94 V-0; Housing Color: Natural; Housing Material: Nylon; Length: 13.9mm; Library Name: Altium Content Vault; Manufacturer Part Number: 52207-0660; Material: Bronze; Max Operating Temperature: 85°C; Min Operating Temperature: -30°C; Mounting Technology: Surface Mount; Number of Circuits: 6; Number of Contacts: 6; Number of Positions: 6; Number of Rows: 1; Orientation: Right Angle; Package Quantity: 1000; PackageDescription: 6-Lead FPC Connector, Pitch 1.0 mm; PackageReference: 52207-0660; PackageVersion: Rev. F, 03/2007; Packaging: Tape and Reel; Packing: Tape and Reel; PartNumber: 52207-0660; Pitch - Termination Interface: 1.00mm; Polarized to PCB: Yes; Pricing 1: 1=0,84000, 10=0,71400, 100=0,65200, 500=0,54300, 1000=0,46600, 2000=0,43500 (USD); REACH SVHC: Unknown; RoHS: TRUE; Stackable: No; Stock 1: 3668; Supplier 1: Mouser; Supplier Part Number 1: 538-52207-0660; Termination: SMD/SMT; Voltage Rating: 125V
J4 = CMP-2000-05120-1: Micro USB B Type Receptacle, 1.8 A, -55 to 85 degC, 5-Pin SMD, RoHS, Tape and Reel; Manufacturer: Amphenol FCI; Body Orientation: RightAngle; Connector Type: USB,Receptacle; Current Rating: 1.8A; Gender: RCP; Insulation Resistance: 1GR; Max Voltage Rating (AC): 100V; Number of Contacts: 5; Number of Ports: 1; Pitch: 0.65mm; Rad Hard: No; Category: Connectors; Color: Black; Component Kind: Standard; ComponentLink1Description: https://datasheet.ciiva.com/4598/10118194ac-197217-4598807.pdf?src-supplier=Mouser; ComponentLink1URL: https://datasheet.ciiva.com/4598/10118194ac-197217-4598807.pdf?src-supplier=Mouser; ComponentLink2Description: https://datasheet.ciiva.com/26945/getdatasheetpartid-418619-26945695.pdf?src-supplier=Verical; ComponentLink2URL: https://datasheet.ciiva.com/26945/getdatasheetpartid-418619-26945695.pdf?src-supplier=Verical; ComponentLink3Description: https://datasheet.ciiva.com/851/10118194-851110.pdf?src-supplier=Digi-Key; ComponentLink3URL: https://datasheet.ciiva.com/851/10118194-851110.pdf?src-supplier=Digi-Key; ComponentLink4Description: https://datasheet.ciiva.com/851/10118194-851111.pdf?src-supplier=Digi-Key; ComponentLink4URL: https://datasheet.ciiva.com/851/10118194-851111.pdf?src-supplier=Digi-Key; Contact Material: Copper Alloy; Contact Plating: Gold; Datasheet URL: https://datasheet.ciiva.com/26945/getdatasheetpartid-418619-26945695.pdf; Datasheet Version: Rev. A, 10/2011; Depth: 5mm; Features: Shielded; Flammability Rating: UL94 V-0; Housing Material: Polymer; Lead Free: Lead Free; Length: 8.05mm; Library Name: Altium Content Vault; Manufacturer Part Number: 10118194-0001LF; Manufacturer URL: http://www.fci.com/; Max Operating Temperature: 85°C; Min Operating Temperature: -55°C; Mount: Surface Mount,Horizontal; Mounting: SurfaceMount; Number of Terminals: 5; Orientation: Right Angle; Package Description: 5-Pin Surface Mount Device, Body 8.05 x 5.53 mm, Pitch 0.65 mm; Package Quantity: 9600; Package Reference: 10118194-0001LF-5; Packaging: CutTape; Pricing 1: 1=0,43000, 10=0,32000, 100=0,26600, 1200=0,18900, 2400=0,18900, 9600=0,18900 (USD); Radiation Hardening: No; RoHS: Yes; Stock 1: 18174; Supplier 1: Mouser; Supplier Part Number 1: 649-10118194-0001LF; Termination: Solder; Termination Method: Solder; Type: MicroUSBTypeB
J5 J6 = Power_Slot: AWG 22; Component Kind: Standard; Library Name: IntegratedLibProject_Orbita.IntLib
J7-J11 = Prog_Slot: Component Kind: Standard; Library Name: IntegratedLibProject_Orbita.IntLib
L1 = CMP-2000-05964-1: Wire-Wound Chip Power Inductor, 2.2 uH, +/- 20%, -40 to 105 degC, 2-Pin SMD (0805), RoHS, Tape and Reel; Manufacturer: Taiyo Yuden; Core Material: Ferrite; Current - Saturation: 1.1A; Current Rating: 1A; DC Resistance (DCR): 143mOhmMax; EDA / CAD Models: DownloadfromAcceleratedDesigns; Frequency - Self Resonant: 350MHz; Frequency - Test: 1MHz; Online Catalog: BRSeries; Other Names: 587-2905-2  LQBRC2012T2R2MD; Shielding: Unshielded; Type: Wirewound; Case Code (Imperial): 0805; Case Code (Metric): 2012; Case/Package: 0805; Category: Inductors,Coils,Chokes; Component Kind: Standard; ComponentLink1Description: https://datasheet.ciiva.com/6748/brc2012t2r2md-ss-6748784.pdf?src-supplier=Newark; ComponentLink1URL: https://datasheet.ciiva.com/6748/brc2012t2r2md-ss-6748784.pdf?src-supplier=Newark; ComponentLink2Description: https://datasheet.ciiva.com/25607/0900766b810b3c54-25607837.pdf?src-supplier=RSComponents; ComponentLink2URL: https://datasheet.ciiva.com/25607/0900766b810b3c54-25607837.pdf?src-supplier=RSComponents; ComponentLink3Description: https://datasheet.ciiva.com/26870/getdatasheetpartid-639072-26870958.pdf?src-supplier=Verical; ComponentLink3URL: https://datasheet.ciiva.com/26870/getdatasheetpartid-639072-26870958.pdf?src-supplier=Verical; ComponentLink4Description: https://datasheet.ciiva.com/1042/wound07-e-1042307.pdf?src-supplier=Digi-Key; ComponentLink4URL: https://datasheet.ciiva.com/1042/wound07-e-1042307.pdf?src-supplier=Digi-Key; ComponentLink5Description: https://datasheet.ciiva.com/1042/wound07-e-1042308.pdf?src-supplier=Digi-Key; ComponentLink5URL: https://datasheet.ciiva.com/1042/wound07-e-1042308.pdf?src-supplier=Digi-Key; ComponentLink6Description: https://datasheet.ciiva.com/1042/wound07-e-1042306.pdf?src-supplier=Digi-Key; ComponentLink6URL: https://datasheet.ciiva.com/1042/wound07-e-1042306.pdf?src-supplier=Digi-Key; Composition: Wirewound; Datasheet URL: http://ds.yuden.co.jp/TYCOMPAS/ut/detail.do?productNo=BRC2012T2R2MD&fileName=BRC2012T2R2MD_SS&mode=specSheetDownload; Datasheet Version: 09/2016; DC Current: 1A; Depth: 1.45mm; Family: FixedInductors; Halogen Free: Halogen Free; Height: 1.4mm; Height - Seated (Max): 0.055"(1.40mm); Inductance Tolerance: 20%; Lead Free: Lead Free; Lead Pitch: 1mm; Length: 2mm; Library Name: Altium Content Vault; Manufacturer Part Number: BRC2012T2R2MD; Manufacturer URL: http://www.t-yuden.com/; Max DC Current: 1A; Max Operating Temperature: 105°C; Military Standard: Not; Min Operating Temperature: -40°C; Mount: Surface Mount; Mounting Technology: SurfaceMount; Mounting Type: SurfaceMount; Number of Pins: 2; Operating Temperature: -40°C~105°C; Package / Case: 0805(2012Metric); Package Description: 2-Pin Surface Mount Device, Body 2 x 1.25 mm; Package Quantity: 2000; Package Reference: 0805; Packaging: Tape&Reel(TR); Pricing 1: 1=0,34000, 10=0,22500, 100=0,18700, 500=0,17600, 1000=0,13500, 2000=0,12000 (USD); Radiation Hardening: No; REACH SVHC: Unknown; RoHS: Yes; Saturation Current: 1.1A; Self Resonant Frequency: 350MHz; Series: BR; Size / Dimension: 0.079"Lx0.049"W(2.00mmx1.25mm); Standard Package: 2,000; Stock 1: 41696; Supplier 1: Mouser; Supplier Part Number 1: 963-BRC2012T2R2MD; Termination: SMD/SMT; Test Frequency: 1MHz; Tolerance: ±20%; Width: 0.049inch
Q1 = DTC143ZMT2L: Transistor PREBIAS NPN 150MW VMT3; Manufacturer: Rohm; Collector Emitter Breakdown Voltage: 50V; Collector Emitter Voltage (VCEO): 300mV; Continuous Collector Current: 100mA; Element Configuration: Single; hFE Min: 80; Transition Frequency: 250MHz; Case/Package: SOT-723; Category: BJTs; Component Kind: Standard; ComponentLink1Description: https://datasheet.ciiva.com/4461/dtc143ze-210891-4461009.pdf?src-supplier=Mouser; ComponentLink1URL: https://datasheet.ciiva.com/4461/dtc143ze-210891-4461009.pdf?src-supplier=Mouser; ComponentLink2Description: https://datasheet.ciiva.com/987/dtc143z-987431.pdf?src-supplier=Digi-Key; ComponentLink2URL: https://datasheet.ciiva.com/987/dtc143z-987431.pdf?src-supplier=Digi-Key; ComponentLink3Description: https://datasheet.ciiva.com/16432/dtc143ze-16432631.pdf?src-supplier=Future+Electronics; ComponentLink3URL: https://datasheet.ciiva.com/16432/dtc143ze-16432631.pdf?src-supplier=Future+Electronics; ComponentLink4Description: https://datasheet.ciiva.com/987/dtc143z-987432.pdf?src-supplier=Digi-Key; ComponentLink4URL: https://datasheet.ciiva.com/987/dtc143z-987432.pdf?src-supplier=Digi-Key; ComponentLink5Description: https://datasheet.ciiva.com/987/dtc143z-987433.pdf?src-supplier=Digi-Key; ComponentLink5URL: https://datasheet.ciiva.com/987/dtc143z-987433.pdf?src-supplier=Digi-Key; Current Rating: 100mA; Lead Free: Lead Free; Manufacturer Part Number: DTC143ZMT2L; Max Breakdown Voltage: 50V; Max Collector Current: 100mA; Max Operating Temperature: 150°C; Max Output Current: 100mA; Max Power Dissipation: 150mW; Min Operating Temperature: -55°C; Mount: Surface Mount; Number of Pins: 3; Operating Supply Voltage: 50V; Package Quantity: 1; Packaging: Tape and Reel; Polarity: NPN; Power Dissipation: 150mW; Pricing 1: 750=0,07900, 1500=0,05500, 3750=0,05000, 7500=0,04600 (EUR); Radiation Hardening: No; REACH SVHC: No SVHC; RoHS: Yes; Stock 1: 7400; Supplier 1: RSComponents; Supplier Part Number 1: 1246561P; Termination: SMD/SMT; Voltage Rating (DC): 50V; Library Name: IntegratedLibProject_Orbita.IntLib
R12 R14 = CMP-2000-07588-1: Chip Resistor, 10 KOhm, +/- 1%, 0.1 W, -55 to 155 degC, 0402 (1005 Metric), RoHS, Tape and Reel; Manufacturer: Panasonic; Manufacturer Part Number: ERJ-2RKF1002X; Case\/Package: 0402; Max Power Dissipation: 100mW; Temperature Coefficient: 100ppm\/degreesC; Voltage Rating: 50V; Case Code (Imperial): 0402; Case Code (Metric): 1005; Case/Package: 0402; Category: Chip SMD Resistors; Component Kind: Standard; ComponentLink1Description: https://datasheet.ciiva.com/11821/0900766b80f80784-11821171.pdf?src-supplier=RSComponents; ComponentLink1URL: https://datasheet.ciiva.com/11821/0900766b80f80784-11821171.pdf?src-supplier=RSComponents; ComponentLink2Description: https://datasheet.ciiva.com/16199/aoa0000ce2-16199478.pdf?src-supplier=Future+Electronics; ComponentLink2URL: https://datasheet.ciiva.com/16199/aoa0000ce2-16199478.pdf?src-supplier=Future+Electronics; ComponentLink3Description: https://datasheet.ciiva.com/17212/aoa0000ce2-17212102.pdf?src-supplier=Avnet+Europe; ComponentLink3URL: https://datasheet.ciiva.com/17212/aoa0000ce2-17212102.pdf?src-supplier=Avnet+Europe; ComponentLink4Description: https://datasheet.ciiva.com/24023/aoa0000ce2-24023017.pdf?src-supplier=Mouser; ComponentLink4URL: https://datasheet.ciiva.com/24023/aoa0000ce2-24023017.pdf?src-supplier=Mouser; ComponentLink5Description: https://datasheet.ciiva.com/28514/1678782-28514185.pdf?src-supplier=Element14; ComponentLink5URL: https://datasheet.ciiva.com/28514/1678782-28514185.pdf?src-supplier=Element14; ComponentLink6Description: https://datasheet.ciiva.com/43467/1678782-43467402.pdf?src-supplier=Farnell; ComponentLink6URL: https://datasheet.ciiva.com/43467/1678782-43467402.pdf?src-supplier=Farnell; ComponentLink7Description: https://datasheet.ciiva.com/27055/1987020-27055643.pdf?src-supplier=Jameco; ComponentLink7URL: https://datasheet.ciiva.com/27055/1987020-27055643.pdf?src-supplier=Jameco; ComponentLink8Description: https://datasheet.ciiva.com/48531/aoa0000ce2-48531069.pdf?src-supplier=Avnet; ComponentLink8URL: https://datasheet.ciiva.com/48531/aoa0000ce2-48531069.pdf?src-supplier=Avnet; ComponentLink9Description: https://datasheet.ciiva.com/9194/aoa0000ce2-9194142.pdf?src-supplier=Avnet; ComponentLink9URL: https://datasheet.ciiva.com/9194/aoa0000ce2-9194142.pdf?src-supplier=Avnet; ComponentLink10Description: https://datasheet.ciiva.com/16782/70260748-16782590.pdf?src-supplier=Allied+Electronics; ComponentLink10URL: https://datasheet.ciiva.com/16782/70260748-16782590.pdf?src-supplier=Allied+Electronics; ComponentLink11Description: https://datasheet.ciiva.com/16786/70260748-16786734.pdf?src-supplier=Allied+Electronics; ComponentLink11URL: https://datasheet.ciiva.com/16786/70260748-16786734.pdf?src-supplier=Allied+Electronics; ComponentLink12Description: https://datasheet.ciiva.com/30201/aoa0000ce2-30201022.pdf?src-supplier=Onlinecomponents.com; ComponentLink12URL: https://datasheet.ciiva.com/30201/aoa0000ce2-30201022.pdf?src-supplier=Onlinecomponents.com; Composition: ThickFilm; Contact Plating: Tin; Datasheet URL: https://industrial.panasonic.com/cdbs/www-data/pdf/RDA0000/AOA0000C304.pdf; Datasheet Version: 02/2017; Depth: 0.5mm; Features: Automotive AEC-Q200; Height: 0.35mm; Lead Free: LeadFree; Length: 1mm; Library Name: Altium Content Vault; Manufacturer URL: http://www.panasonic.com/; Max Operating Temperature: 155degC; Military Standard: Not; Min Operating Temperature: -55degC; Mount: SurfaceMount; Mounting Technology: SurfaceMount; Number of Pins: 2; Number of Terminations: 2; Package Description: 2-Pin Surface Mount Device, Body 1 x 0.5 mm; Package Quantity: 1; Package Reference: 402; Packaging: TapeandReel; Power Rating: 100mW; Pricing 1: 1=0,10000, 10=0,04500, 100=0,01800, 1000=0,00800, 2500=0,00500, 10000=0,00400 (USD); Radiation Hardening: No; REACH SVHC: NoSVHC; RoHS: Yes; RoHSCompliant: True; Stock 1: 3749376; Supplier 1: Mouser; Supplier Part Number 1: 667-ERJ-2RKF1002X; Termination: SMD/SMT; Tolerance: 1%; Voltage Rating (DC): 50V; Width: 0.02inch
R13 = CMP-1011-00397-1: 100R 0.063W 1% 0402 (1005 Metric)  SMD; Manufacturer: Vishay Dale; Manufacturer Part Number: CRCW0402100RFKED; Case-EIA: 0402; Case-Metric: 1005; Max Power Dissipation: 63mW; Temperature Coefficient: 100ppm/°C; Value: 100.00 Ohm; Voltage Rating: 50V; Case Code (Imperial): 0402; Case Code (Metric): 1005; Case/Package: 0402; Category: Chip SMD Resistors; Component Kind: Standard; ComponentLink1Description: https://datasheet.ciiva.com/11809/0900766b80d98824-11809081.pdf?src-supplier=RSComponents; ComponentLink1URL: https://datasheet.ciiva.com/11809/0900766b80d98824-11809081.pdf?src-supplier=RSComponents; ComponentLink2Description: https://datasheet.ciiva.com/16199/dcrcwe3-16199158.pdf?src-supplier=Future+Electronics; ComponentLink2URL: https://datasheet.ciiva.com/16199/dcrcwe3-16199158.pdf?src-supplier=Future+Electronics; ComponentLink3Description: https://datasheet.ciiva.com/5552/dcrcwe3-109170-5552168.pdf?src-supplier=Mouser; ComponentLink3URL: https://datasheet.ciiva.com/5552/dcrcwe3-109170-5552168.pdf?src-supplier=Mouser; ComponentLink4Description: https://datasheet.ciiva.com/2463/dcrcwe3-2463095.pdf?src-supplier=Digi-Key; ComponentLink4URL: https://datasheet.ciiva.com/2463/dcrcwe3-2463095.pdf?src-supplier=Digi-Key; ComponentLink5Description: https://datasheet.ciiva.com/2463/dcrcwe3-2463096.pdf?src-supplier=Digi-Key; ComponentLink5URL: https://datasheet.ciiva.com/2463/dcrcwe3-2463096.pdf?src-supplier=Digi-Key; ComponentLink6Description: https://datasheet.ciiva.com/8083/dcrcwe3-8083977.pdf?src-supplier=Avnet; ComponentLink6URL: https://datasheet.ciiva.com/8083/dcrcwe3-8083977.pdf?src-supplier=Avnet; ComponentLink7Description: https://datasheet.ciiva.com/16722/70201119-16722524.pdf?src-supplier=Allied+Electronics; ComponentLink7URL: https://datasheet.ciiva.com/16722/70201119-16722524.pdf?src-supplier=Allied+Electronics; ComponentLink8Description: https://datasheet.ciiva.com/22673/1697337-22673117.pdf?src-supplier=Element14; ComponentLink8URL: https://datasheet.ciiva.com/22673/1697337-22673117.pdf?src-supplier=Element14; ComponentLink9Description: https://datasheet.ciiva.com/3255/dcrcwe3-3255951.pdf?src-supplier=Avnet; ComponentLink9URL: https://datasheet.ciiva.com/3255/dcrcwe3-3255951.pdf?src-supplier=Avnet; ComponentLink10Description: https://datasheet.ciiva.com/2463/dcrcwe3-2463094.pdf?src-supplier=Digi-Key; ComponentLink10URL: https://datasheet.ciiva.com/2463/dcrcwe3-2463094.pdf?src-supplier=Digi-Key; ComponentLink11Description: https://datasheet.ciiva.com/22673/1697337-22673118.pdf?src-supplier=Element14; ComponentLink11URL: https://datasheet.ciiva.com/22673/1697337-22673118.pdf?src-supplier=Element14; Composition: Thick Film; Contact Plating: Tin; Depth: 0.5mm; Features: Automotive AEC-Q200; Height: 0.35mm; Lead Free: Lead Free; Length: 1mm; Library Name: Altium Content Vault; Max Operating Temperature: 155°C; Military Standard: Not; Min Operating Temperature: -55°C; Mount: Surface Mount; Number of Terminations: 2; Package Quantity: 10; Packaging: Tape and Reel; Power: 0.063 W; Power Rating: 63mW; Pricing 1: 1=0,10000, 10=0,03900, 100=0,02700, 1000=0,01500, 10000=0,01000, 30000=0,00800 (USD); Radiation Hardening: No; REACH SVHC: Unknown; RoHS: Yes; Stock 1: 74003; Supplier 1: Mouser; Supplier Part Number 1: 71-CRCW0402-100-E3; Technology: SMT; Termination: SMD/SMT; Tolerance: 1 %; Voltage Rating (DC): 50V; Weight: 2.3E-05oz; Width: 0.02inch; Working Voltage: 50V
R15 = CMP-2002-00074-1: Manufacturer: Vishay Dale; Max Power Dissipation: 63mW; Temperature Coefficient: 100ppm/°C; Tolerance: 1%; Voltage Rating: 50V; Case Code (Imperial): 0402; Case Code (Metric): 1005; Case/Package: 0402; Category: Chip SMD Resistors; Component Kind: Standard; ComponentLink1Description: https://datasheet.ciiva.com/11818/0900766b80d98824-11818079.pdf?src-supplier=RSComponents; ComponentLink1URL: https://datasheet.ciiva.com/11818/0900766b80d98824-11818079.pdf?src-supplier=RSComponents; ComponentLink2Description: https://datasheet.ciiva.com/2463/dcrcwe3-2463312.pdf?src-supplier=Digi-Key; ComponentLink2URL: https://datasheet.ciiva.com/2463/dcrcwe3-2463312.pdf?src-supplier=Digi-Key; ComponentLink3Description: https://datasheet.ciiva.com/3256/dcrcwe3-3256613.pdf?src-supplier=Avnet; ComponentLink3URL: https://datasheet.ciiva.com/3256/dcrcwe3-3256613.pdf?src-supplier=Avnet; ComponentLink4Description: https://datasheet.ciiva.com/16881/dcrcwe3-16881749.pdf?src-supplier=Avnet+Europe; ComponentLink4URL: https://datasheet.ciiva.com/16881/dcrcwe3-16881749.pdf?src-supplier=Avnet+Europe; ComponentLink5Description: https://datasheet.ciiva.com/5549/dcrcwe3-109170-5549974.pdf?src-supplier=Mouser; ComponentLink5URL: https://datasheet.ciiva.com/5549/dcrcwe3-109170-5549974.pdf?src-supplier=Mouser; ComponentLink6Description: https://datasheet.ciiva.com/22705/1697337-22705163.pdf?src-supplier=Element14; ComponentLink6URL: https://datasheet.ciiva.com/22705/1697337-22705163.pdf?src-supplier=Element14; ComponentLink7Description: https://datasheet.ciiva.com/16436/dcrcwe3-16436124.pdf?src-supplier=Future+Electronics; ComponentLink7URL: https://datasheet.ciiva.com/16436/dcrcwe3-16436124.pdf?src-supplier=Future+Electronics; ComponentLink8Description: https://datasheet.ciiva.com/16754/70201119-16754716.pdf?src-supplier=Allied+Electronics; ComponentLink8URL: https://datasheet.ciiva.com/16754/70201119-16754716.pdf?src-supplier=Allied+Electronics; ComponentLink9Description: https://datasheet.ciiva.com/2463/dcrcwe3-2463310.pdf?src-supplier=Digi-Key; ComponentLink9URL: https://datasheet.ciiva.com/2463/dcrcwe3-2463310.pdf?src-supplier=Digi-Key; ComponentLink10Description: https://datasheet.ciiva.com/2463/dcrcwe3-2463311.pdf?src-supplier=Digi-Key; ComponentLink10URL: https://datasheet.ciiva.com/2463/dcrcwe3-2463311.pdf?src-supplier=Digi-Key; Composition: Thick Film; Contact Plating: Tin; Depth: 0.5mm; Features: Automotive AEC-Q200; Height: 0.35mm; Lead Free: Lead Free; Length: 1mm; Library Name: Altium Content Vault; Manufacturer Part Number: CRCW0402510RFKED; Max Operating Temperature: 155°C; Military Standard: Not; Min Operating Temperature: -55°C; Mount: Surface Mount; Number of Terminations: 2; Package Quantity: 10000; Packaging: Tape and Reel; Part Number: CRCW0402510RFKED; Power Rating: 63mW; Pricing 1: 1=0,10000, 10=0,03700, 100=0,01500, 500=0,01000, 1000=0,00600, 2500=0,00500 (USD); Radiation Hardening: No; REACH SVHC: Unknown; RoHS: Yes; Stock 1: 7067; Supplier 1: Mouser; Supplier Part Number 1: 71-CRCW0402-510-E3; Termination: SMD/SMT; Voltage Rating (DC): 50V; Weight: 2.3E-05oz; Width: 0.02inch
